# Altium schematic sheet Com_MCU.SchDoc
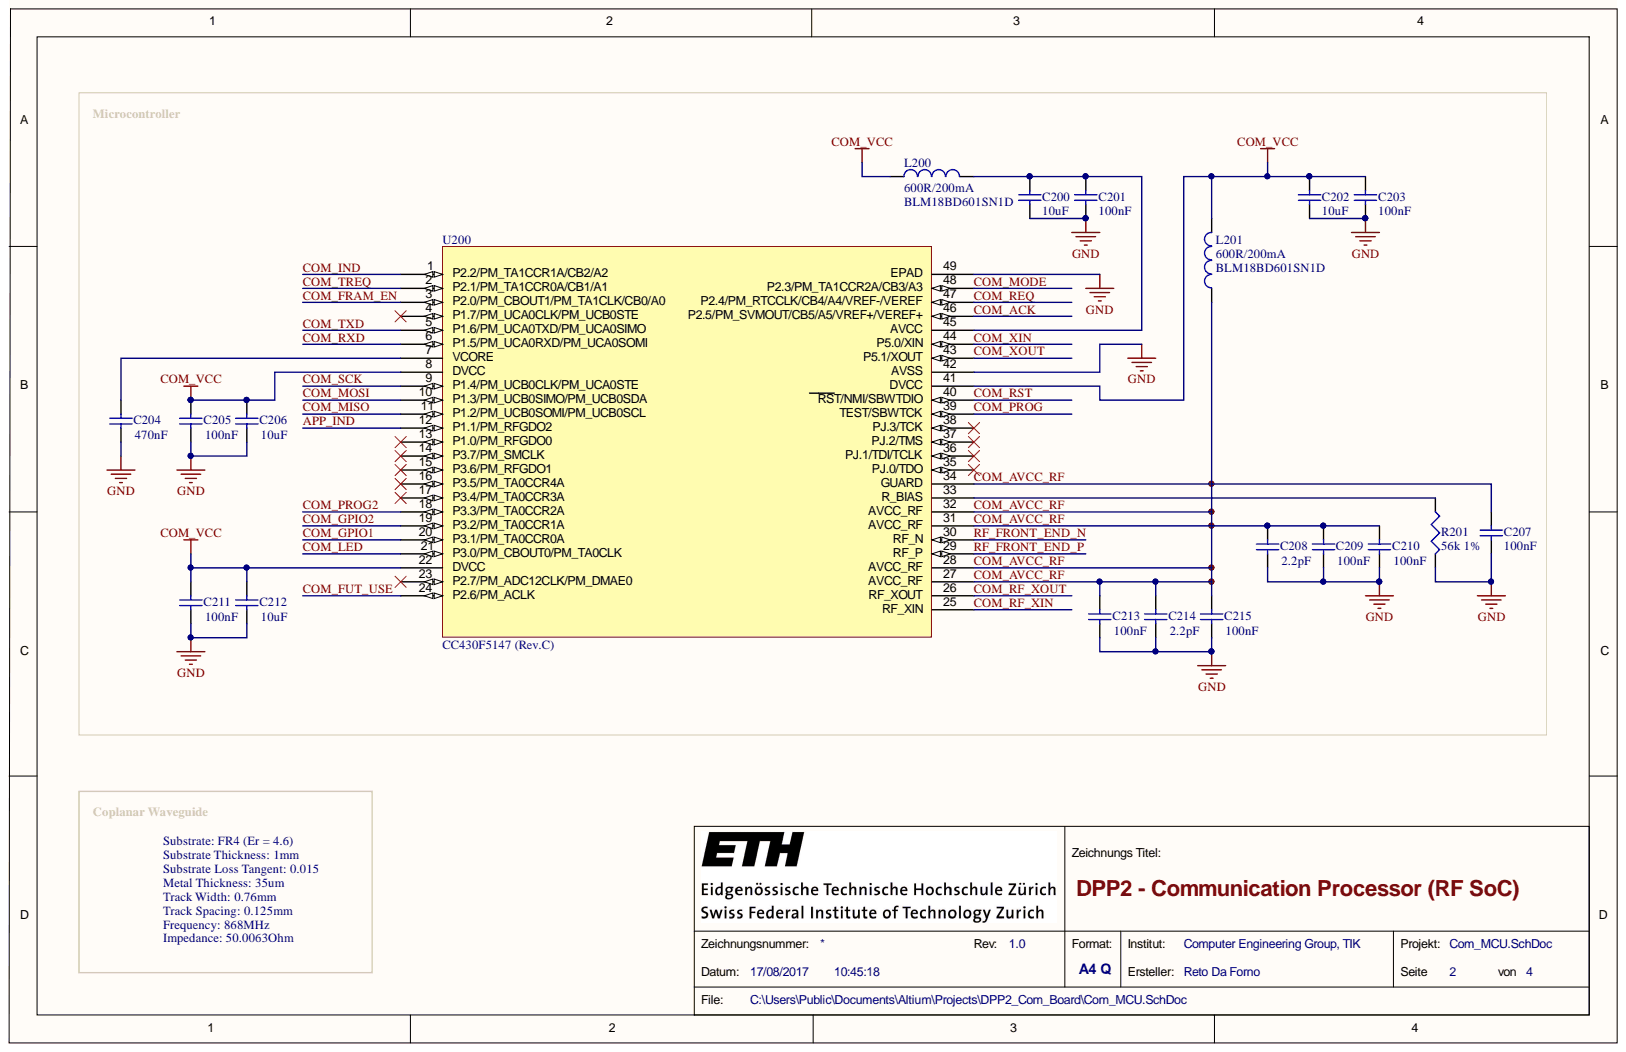
[diagram p0: Com_MCU.SchDoc]

## Parts
C200  10uF  [0402_N]
C201  100nF  [0402_N]
C202  10uF  [0402_N]
C203  100nF  [0402_N]
C204  470nF  [0402_N]
C205  100nF  [0402_N]
C206  10uF  [0402_N]
C207  100nF  [0402_N]
C208  2.2pF  [0402_N]
C209  100nF  [0402_N]
C210  100nF  [0402_N]
C211  100nF  [0402_N]
C212  10uF  [0402_N]
C213  100nF  [0402_N]
C214  2.2pF  [0402_N]
C215  100nF  [0402_N]
L200  600R/200mA  value BLM18BD601SN1D  [0603_N]
L201  600R/200mA  value BLM18BD601SN1D  PartNumber: BLM18BD601SN1D  [0603_N]
R201  56k 1%  [0402_N]
U200  CC430F5147 (Rev.C)  [RGZ_48]

## Nets
COM_VCC: C202.2 C203.2 C205.1 C206.1 C211.1 C212.1 L200.1 L201.2 U200.8(DVCC) U200.22(DVCC) U200.41(DVCC)
GND: C200.1 C201.1 C202.1 C203.1 C204.2 C205.2 C206.2 C207.2 C208.1 C209.1 C210.1 C211.2 C212.2 C213.2 C214.2 C215.2 R201.2 U200.42(AVSS) U200.49(EPAD)
APP_IND: U200.12(P1.1/PM_RFGDO2)
COM_ACK: U200.46(P2.5/PM_SVMOUT/CB5/A5/VREF+/VEREF+)
COM_AVCC_RF: C207.1 C208.2 C209.2 C210.2 C213.1 C214.1 C215.1 L201.1 U200.27(AVCC_RF) U200.28(AVCC_RF) U200.31(AVCC_RF) U200.32(AVCC_RF) U200.34(GUARD)
COM_FRAM_EN: U200.3(P2.0/PM_CBOUT1/PM_TA1CLK/CB0/A0)
COM_FUT_USE: U200.24(P2.6/PM_ACLK)
COM_GPIO1: U200.20(P3.1/PM_TA0CCR0A)
COM_GPIO2: U200.19(P3.2/PM_TA0CCR1A)
COM_IND: U200.1(P2.2/PM_TA1CCR1A/CB2/A2)
COM_LED: U200.21(P3.0/PM_CBOUT0/PM_TA0CLK)
COM_MISO: U200.11(P1.2/PM_UCB0SOMI/PM_UCB0SCL)
COM_MODE: U200.48(P2.3/PM_TA1CCR2A/CB3/A3)
COM_MOSI: U200.10(P1.3/PM_UCB0SIMO/PM_UCB0SDA)
COM_PROG: U200.39(TEST/SBWTCK)
COM_PROG2: U200.18(P3.3/PM_TA0CCR2A)
COM_REQ: U200.47(P2.4/PM_RTCCLK/CB4/A4/VREF-/VEREF)
COM_RF_XIN: U200.25(RF_XIN)
COM_RF_XOUT: U200.26(RF_XOUT)
COM_RST: U200.40(RST/NMI/SBWTDIO)
COM_RXD: U200.6(P1.5/PM_UCA0RXD/PM_UCA0SOMI)
COM_SCK: U200.9(P1.4/PM_UCB0CLK/PM_UCA0STE)
COM_TREQ: U200.2(P2.1/PM_TA1CCR0A/CB1/A1)
COM_TXD: U200.5(P1.6/PM_UCA0TXD/PM_UCA0SIMO)
COM_XIN: U200.44(P5.0/XIN)
COM_XOUT: U200.43(P5.1/XOUT)
RF_FRONT_END_N: U200.30(RF_N)
RF_FRONT_END_P: U200.29(RF_P)
NetC200_2: C200.2 C201.2 L200.2 U200.45(AVCC)
NetC204_1: C204.1 U200.7(VCORE)
NetR201_1: R201.1 U200.33(R_BIAS)

Not connected: U200 4(P1.7/PM_UCA0CLK/PM_UCB0STE) 13(P1.0/PM_RFGDO0) 14(P3.7/PM_SMCLK) 15(P3.6/PM_RFGDO1) 16(P3.5/PM_TA0CCR4A) 17(P3.4/PM_TA0CCR3A) 23(P2.7/PM_ADC12CLK/PM_DMAE0) 35(PJ.0/TDO) 36(PJ.1/TDI/TCLK) 37(PJ.2/TMS) 38(PJ.3/TCK)

## Library data of the parts
C200-C203 C205 C207 C209-C213 C215 = Cap: Capacitor, Ceramic; Component Kind: Standard; LatestRevisionDate: 17-Jul-2002; LatestRevisionNote: Re-released for DXP Platform.; PackageDescription: Radial Cap, Thru-Hole; 2 Leads; 0.3 in Pin Spacing; PackageReference: RAD-0.3; Published: 8-Jun-2000; Signal Integrity: Cap; Simulation: CAP; Library Name: PermaSense.SchLib; Publisher: Altium Limited
C204 = Cap: Capacitor, Ceramic; Manufacturer: Murata; PartNumber: GRM155R71A474KE01D; Component Kind: Standard; LatestRevisionDate: 17-Jul-2002; LatestRevisionNote: Re-released for DXP Platform.; PackageDescription: Radial Cap, Thru-Hole; 2 Leads; 0.3 in Pin Spacing; PackageReference: RAD-0.3; Published: 8-Jun-2000; Signal Integrity: Cap; Simulation: CAP; Library Name: PermaSense.SchLib; Publisher: Altium Limited
C206 = Cap: Capacitor, Ceramic; Manufacturer: Murata; PartNumber: GRM155R60G106ME44D; Component Kind: Standard; LatestRevisionDate: 17-Jul-2002; LatestRevisionNote: Re-released for DXP Platform.; Manufacturer2: Murata; PackageDescription: Radial Cap, Thru-Hole; 2 Leads; 0.3 in Pin Spacing; PackageReference: RAD-0.3; PartNumber2: GRM155R60J106; Published: 8-Jun-2000; Signal Integrity: Cap; Simulation: CAP; Library Name: PermaSense.SchLib; Publisher: Altium Limited
C208 C214 = Cap: Capacitor, Ceramic; Manufacturer: Murata; PartNumber: GRM1555C1H2R2CA01D; Component Kind: Standard; LatestRevisionDate: 17-Jul-2002; LatestRevisionNote: Re-released for DXP Platform.; PackageDescription: Radial Cap, Thru-Hole; 2 Leads; 0.3 in Pin Spacing; PackageReference: RAD-0.3; Published: 8-Jun-2000; Signal Integrity: Cap; Simulation: CAP; Library Name: PermaSense.SchLib; Publisher: Altium Limited
L200 = Inductor: Ferrite Bead; Manufacturer: Murata; PartNumber: BLM18BD601SN1D; Code_IEC: 1005; Value: BLM18BD601SN1D; Code_JEITA: 0402; Component Kind: Standard; LatestRevisionDate: 29-May-2009; LatestRevisionNote: IPC-7351 Footprint Added.; Library Name: Miscellaneous Devices.IntLib; PackageDescription: Chip Inductor; PackageReference: 0402-A; Published: 8-Jun-2000; Signal Integrity: Inductor; Simulation: INDUCTOR; Publisher: Altium Limited
L201 = Inductor: Ferrite Bead; Manufacturer: Murata; Code_IEC: 1005; Code_JEITA: 0402; Component Kind: Standard; LatestRevisionDate: 29-May-2009; LatestRevisionNote: IPC-7351 Footprint Added.; Library Name: Miscellaneous Devices.IntLib; PackageDescription: Chip Inductor; PackageReference: 0402-A; Published: 8-Jun-2000; Signal Integrity: Inductor; Simulation: INDUCTOR; Publisher: Altium Limited
R201 = Res3: Resistor; Manufacturer: Panasonic; PartNumber: ERJ2RKF5602X; Component Kind: Standard; LatestRevisionDate: 29-May-2009; LatestRevisionNote: IPC-7351 Footprint Added.; Library Name: Miscellaneous Devices.IntLib; PackageDescription: Chip Resistor; PackageReference: J1-0603; Published: 8-Jun-2000; Publisher: Altium Limited
U200 = CC430F5137: TI CC430 MCU+Radio; Manufacturer: Texas Instruments; PartNumber: CC430F5147IRGZ; Component Kind: Standard; Library Name: Felix_Component_Library.SchLib
